# Altium schematic sheet FEV-60_LED_Panel.SchDoc
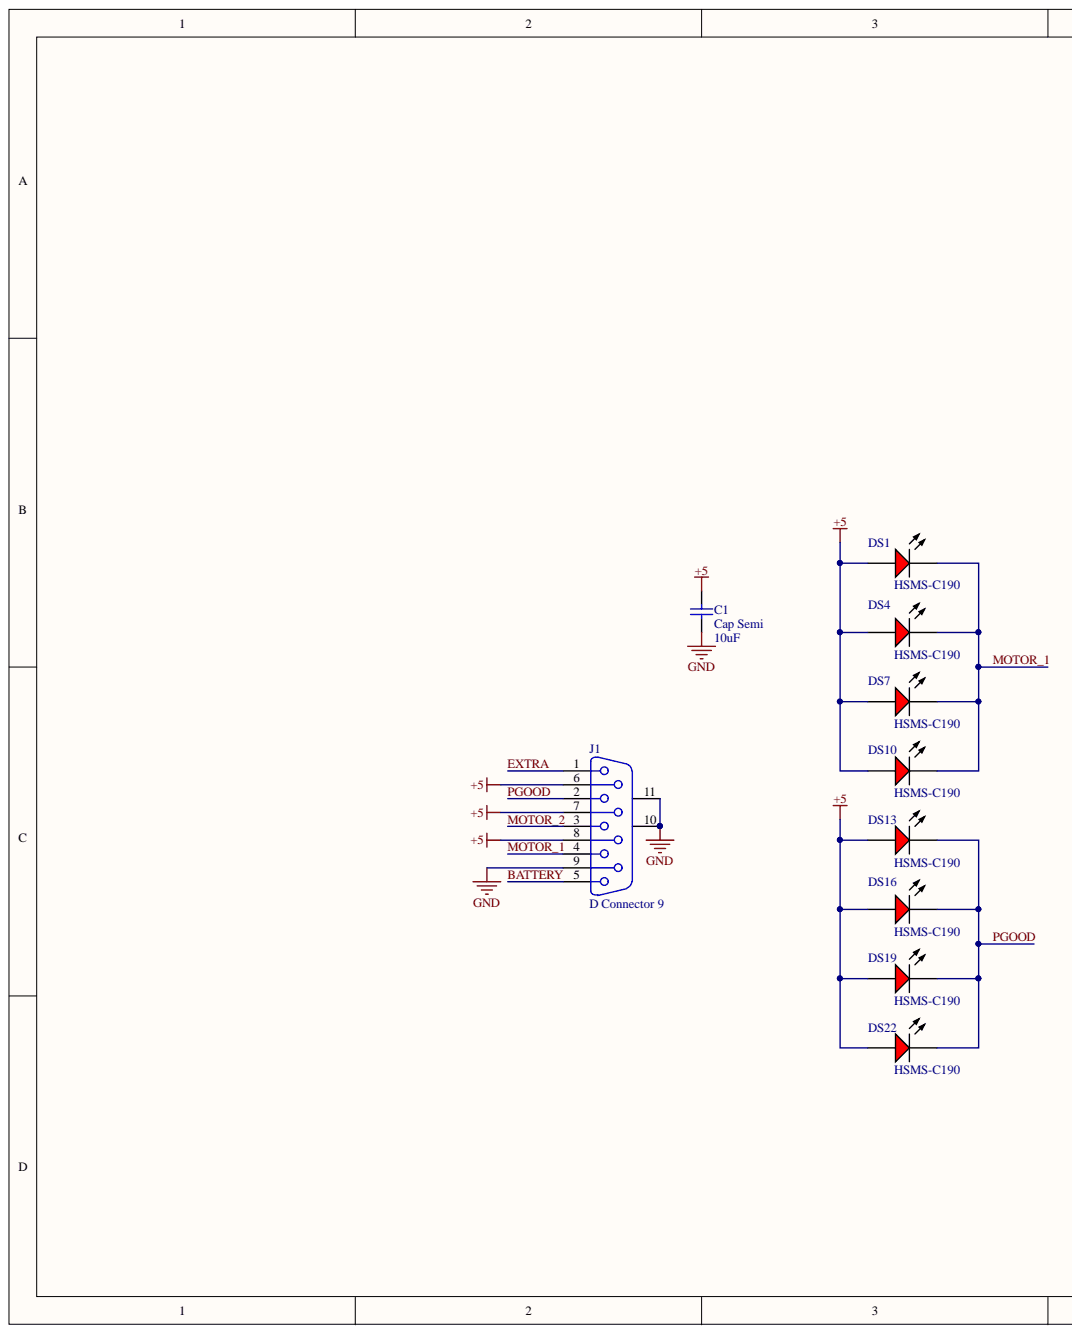
[diagram p0: FEV-60_LED_Panel.SchDoc, left]
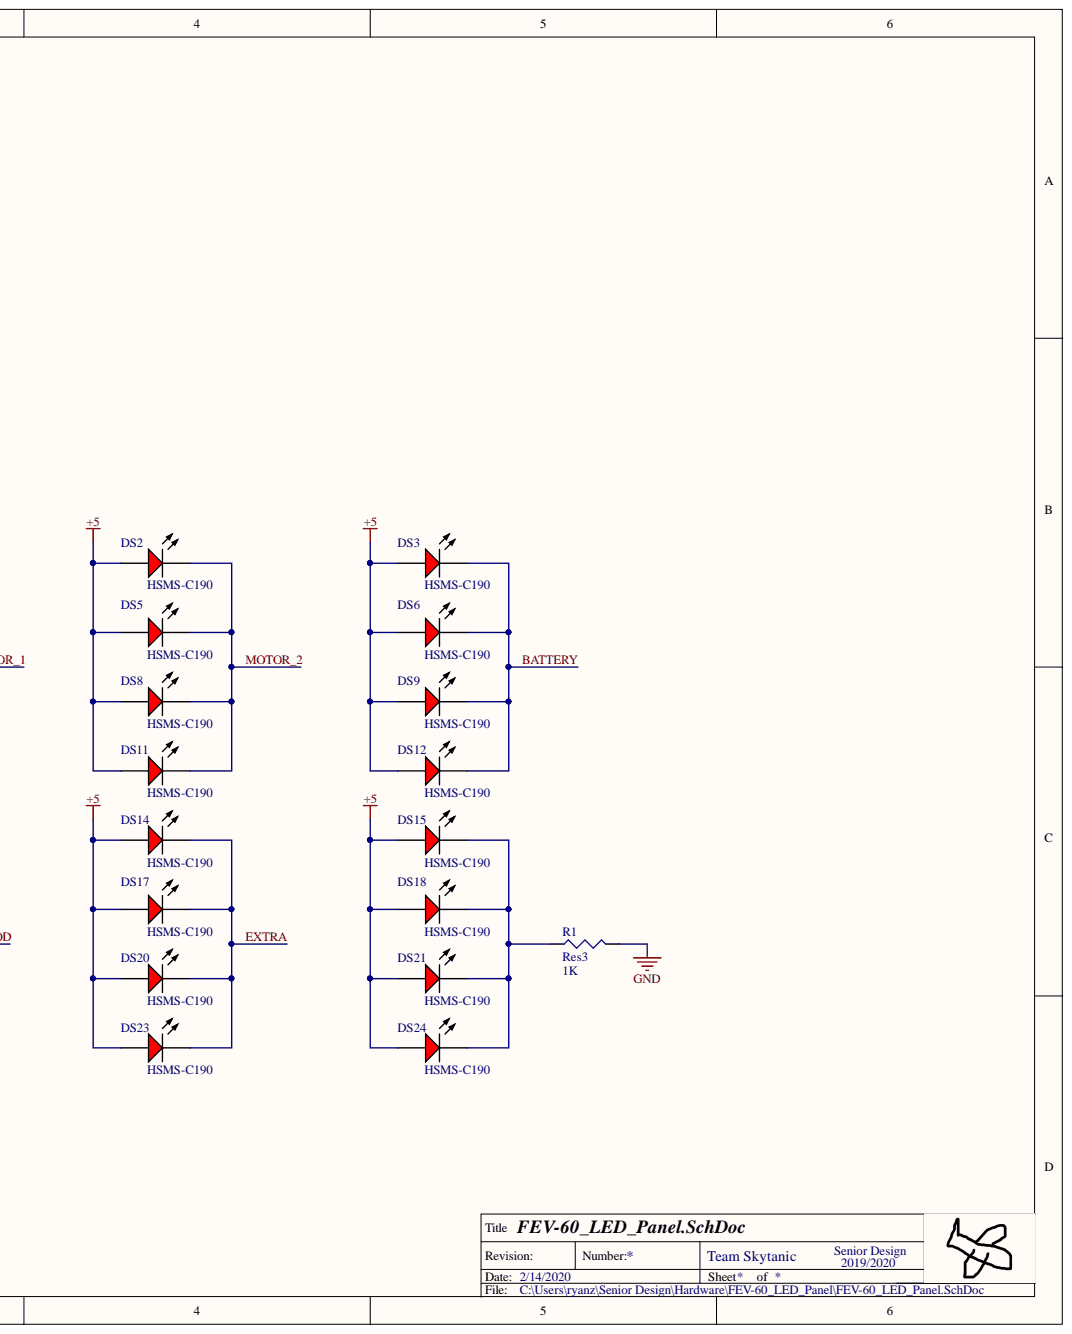
[diagram p1: FEV-60_LED_Panel.SchDoc, right]

## Parts
C1  Cap Semi  value 10uF  [1608[0603]]
DS1  HSMS-C190  [AVAG-HSMX-C190_V]
DS2  HSMS-C190  [AVAG-HSMX-C190_V]
DS3  HSMS-C190  [AVAG-HSMX-C190_V]
DS4  HSMS-C190  [AVAG-HSMX-C190_V]
DS5  HSMS-C190  [AVAG-HSMX-C190_V]
DS6  HSMS-C190  [AVAG-HSMX-C190_V]
DS7  HSMS-C190  [AVAG-HSMX-C190_V]
DS8  HSMS-C190  [AVAG-HSMX-C190_V]
DS9  HSMS-C190  [AVAG-HSMX-C190_V]
DS10  HSMS-C190  [AVAG-HSMX-C190_V]
DS11  HSMS-C190  [AVAG-HSMX-C190_V]
DS12  HSMS-C190  [AVAG-HSMX-C190_V]
DS13  HSMS-C190  [AVAG-HSMX-C190_V]
DS14  HSMS-C190  [AVAG-HSMX-C190_V]
DS15  HSMS-C190  [AVAG-HSMX-C190_V]
DS16  HSMS-C190  [AVAG-HSMX-C190_V]
DS17  HSMS-C190  [AVAG-HSMX-C190_V]
DS18  HSMS-C190  [AVAG-HSMX-C190_V]
DS19  HSMS-C190  [AVAG-HSMX-C190_V]
DS20  HSMS-C190  [AVAG-HSMX-C190_V]
DS21  HSMS-C190  [AVAG-HSMX-C190_V]
DS22  HSMS-C190  [AVAG-HSMX-C190_V]
DS23  HSMS-C190  [AVAG-HSMX-C190_V]
DS24  HSMS-C190  [AVAG-HSMX-C190_V]
J1  D Connector 9  [DSUB1.385-2H9]
R1  Res3  value 1K  [J1-0603]

## Nets
+5: C1.2 DS1.1 DS2.1 DS3.1 DS4.1 DS5.1 DS6.1 DS7.1 DS8.1 DS9.1 DS10.1 DS11.1 DS12.1 DS13.1 DS14.1 DS15.1 DS16.1 DS17.1 DS18.1 DS19.1 DS20.1 DS21.1 DS22.1 DS23.1 DS24.1 J1.6 J1.7 J1.8
GND: C1.1 J1.9 J1.10 J1.11 R1.2
BATTERY: DS3.2 DS6.2 DS9.2 DS12.2 J1.5
EXTRA: DS14.2 DS17.2 DS20.2 DS23.2 J1.1
MOTOR_1: DS1.2 DS4.2 DS7.2 DS10.2 J1.4
MOTOR_2: DS2.2 DS5.2 DS8.2 DS11.2 J1.3
PGOOD: DS13.2 DS16.2 DS19.2 DS22.2 J1.2
NetDS15_2: DS15.2 DS18.2 DS21.2 DS24.2 R1.1

## Library data of the parts
C1 = Cap Semi: Capacitor (Semiconductor SIM Model); Component Kind: Standard; LatestRevisionDate: 29-May-2009; LatestRevisionNote: IPC-7351 Footprint Added.; Library Name: Miscellaneous Devices.IntLib; PackageDescription: Chip Capacitor; PackageReference: C1206; Published: 8-Jun-2000; Signal Integrity: Cap Semi; Simulation: CAP; Publisher: Altium Limited
DS1-DS24 = CMP-2000-06427-1: LED Uni-Color Red, 52 mW, 20 mA, -40 to 85 degC, 2-Pin SMD, RoHS, Tape and Reel; Manufacturer: Avago; Chip Material: GaP; DELETED: Compliant; Dominant Wave Length: 626nm; Forward Current: 25mA; Forward Voltage: 2.6V; High Brightness: No; Lens Type: ColorlessDiffused; Luminous Intensity: 10mcd; Main Category: ChipLED; Number of  Elements: 1; Peak Wavelength: 630nm; Power Dissipation: 52W; Product Depth (mm): 0.8mm; Product Length (mm): 1.6mm; Reverse Voltage: 5V; Shape Type: Rectangular; Test Current (It): 20mA; Viewing Angle: 170deg; Component Kind: Standard; Datasheet URL: http://docs.avagotech.com/docs/AV02-0551EN; Datasheet Version: 03/2012; Emitting Color: Red; Lens Dimensions: 1x0.8x0.5mm; Library Name: Altium Content Vault; Lum Intensity: 10mcd; Manufacturer URL: http://www.avagotech.com/; Mounting: SurfaceMount; Mounting Technology: SurfaceMount; Operating Temperature Classification: Industrial; Operating Temp Range: -40Cto85C; Package Description: 2-Pin Surface Mount Device, Body 1.6 x 0.8 mm, Height 0.9 mm; Package Reference: HSMX-C190; Package Type: ChipLed; Packaging: TapeandReel; Pin Count: 2; Product Height (mm): 0.8mm; Rad Hardened: No; Type: Uni-Color
J1 = D Connector 9: Receptacle Assembly, 9 Position, Right Angle; PackageDocument: O; Positions: 9; Component Kind: Standard; DatasheetDocument: 1-Sep-1999; Gender: Receptacle; LatestRevisionDate: 17-Jul-2002; LatestRevisionNote: Re-released for DXP Platform.; Library Name: Miscellaneous Connectors.IntLib; Orientation: Right Angle; PackageReference: 788750; Published: 15-Jun-2001; Signal Integrity: Connector; Publisher: Altium Limited
R1 = Res3: Resistor; Component Kind: Standard; LatestRevisionDate: 29-May-2009; LatestRevisionNote: IPC-7351 Footprint Added.; Library Name: Miscellaneous Devices.IntLib; PackageDescription: Chip Resistor; PackageReference: J1-0603; Published: 8-Jun-2000; Signal Integrity: Res; Simulation: RESISTOR; Publisher: Altium Limited
